# Altium schematic sheet power.SchDoc
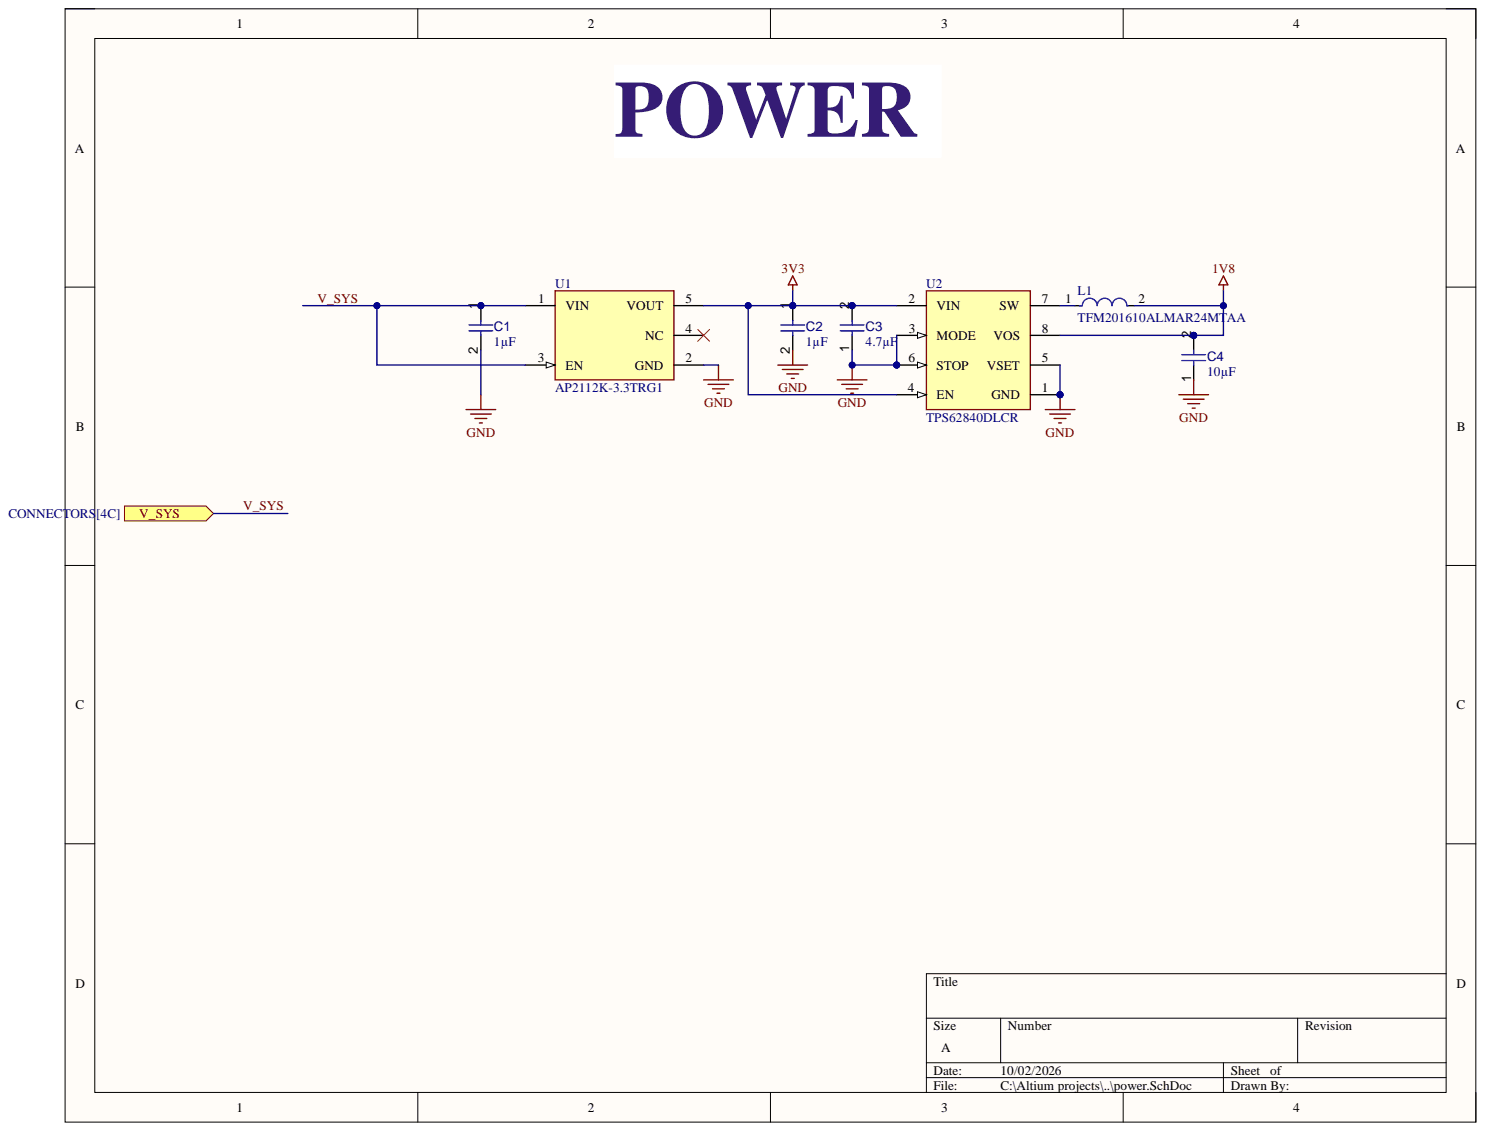
[diagram p0: power.SchDoc]

## Parts
C1  value 1µF  [CAPC1608X94X35NL15T15]  (hidden comment: Capacitor 1 uF +/- 10% 16 V 0603)
C2  value 1µF  [CAPC1608X94X35NL15T15]  (hidden comment: Capacitor 1 uF +/- 10% 16 V 0603)
C3  value 4.7µF  [CAPC0603(1608)100_L]  (hidden comment: Capacitor 4.7µF +/-20% 10V 0603)
C4  value 10µF  [CAPC0603(1608)100_L]  (hidden comment: Capacitor 10µF +/-20% 10V 0603)
L1  TFM201610ALMAR24MTAA  [FP-TFM201610-IPC_B]
U1  AP2112K-3.3TRG1  [FP-SOT25-IPC_A]
U2  TPS62840DLCR  [FP-DLC0008B-MFG]

## Ports
V_SYS

## Nets
1V8: C4.2 L1.2 U2.8(VOS)
3V3: C2.1 C3.2 U1.5(VOUT) U2.2(VIN) U2.4(EN)
GND: C1.2 C2.2 C3.1 C4.1 U1.2(GND) U2.1(GND) U2.3(MODE) U2.5(VSET) U2.6(STOP)
V_SYS: C1.1 U1.1(VIN) U1.3(EN) ; port V_SYS
NetL1_1: L1.1 U2.7(SW)

Not connected: U1 4(NC)

## Library data of the parts
C1 C2 = CMP-001-00003-6: Chip Capacitor, 1 uF, +/- 10%, 16 V, 0603 (1608 Metric); Capacitor Type: Ceramic; Voltage Rating: 16V; Case/Package: 0603; Component Kind: Standard; Max Operating Temperature: 125°C; Min Operating Temperature: -55°C; Mounting Technology: SM; Pins: 2; RoHS Compliant: True; Tolerance: 10%; Library Name: Kopithan Manoranjithan
C3 = CMP-001-00076-4: Chip Capacitor, 4.7µF +/-20%, 10V, 0603, Thickness 1 mm; Tolerance: 10%; Case/Package: 0603; Component Kind: Standard; Max Operating Temperature: 85°C; Min Operating Temperature: -55°C; Mounting Technology: SMT; Pins: 2; Voltage Rating: 10V; Library Name: Kopithan Manoranjithan
C4 = CMP-001-00075-4: Chip Capacitor, 10µF +/-20%, 10V, 0603, Thickness 1 mm; Tolerance: 10%; Case/Package: 0603; Component Kind: Standard; Max Operating Temperature: 85°C; Min Operating Temperature: -55°C; Mounting Technology: SMT; Pins: 2; Voltage Rating: 10V; Library Name: Kopithan Manoranjithan
L1 = CMP-08253-000031-1: FIXED IND 240NH 5A 23 MOHM SMD; Component Kind: Standard; Library Name: Altium Content Vault
U1 = CMP-12756-000035-1: IC REG LINEAR 3.3V 600MA SOT25; Accuracy: 1.5%; Dropout Voltage: 250mV; Max Input Voltage: 6V; Min Input Voltage: 2.5V; Number of Outputs: 1; Output Type: Fixed; Polarity: Positive; Quiescent Current: 55uA; Case/Package: SOT-23-5; Component Kind: Standard; Height: 1.3mm; Lead Free: Lead Free; Length: 3.1mm; Library Name: Altium Content Vault; Max Operating Temperature: 85°C; Max Output Current: 600mA; Min Operating Temperature: -40°C; Mount: Surface Mount; Number of Pins: 5; Number of Regulators: 1; Output Current: 600mA; Output Voltage: 3.3V; Package Quantity: 1; Packaging: Tape and Reel; Width: 1.7mm
U2 = CMP-04918-000086-1: ULTRA LOW IQ BUCK CONVERTER; Manufacturer: Texas Instruments; Max Duty Cycle: 100%; Max Junction Temp: +125°C; Max Supply Voltage: 6.5V; Min Supply Voltage: 1.8V; Nominal Supply Current: 0.005-3000uA; Number Of Outputs: 1; Output Current: 750mA; Output Type: AdjustableProgrammable; Output Voltage: 1.8-3.3V; Standoff Height: 0mm; Switching Frequency: 1.8MHz; Automotive: No; Category: IC; Component Kind: Standard; ComponentLink2Description: Datasheet; ComponentLink2URL: http://www.ti.com/lit/ds/symlink/tps62840.pdf; Device Class L1: Integrated Circuits (ICs); Device Class L2: Power Management ICs; Device Class L3: Voltage Regulators - Switching; Digikey Description: ULTRA LOW IQ BUCK CONVERTER; Height: 1mm; Ipc Land Pattern Name: DFN200X150X100-8; Lead Free: Yes; Library Name: Altium Content Vault; Manufacturer Part Number: TPS62840DLCR; Mouser Description: 60-nA IQ, 1.8-V to 6.5-VIN, high-efficiency 750-mA step-down converter 8-VSON-HR -40 to 125; Octopart: https://octopart.com/tps62840dlcr-texas+instruments-103121111; Package: DLC0008B; RoHS: TRUE; Switching Topology: Buck; Temperature: -40°C to +125°C; Temperature Range High: +125°C; Temperature Range Low: -40°C
